# Altium schematic sheet pay-motors-prototype.SchDoc(pay-motors-prototype)
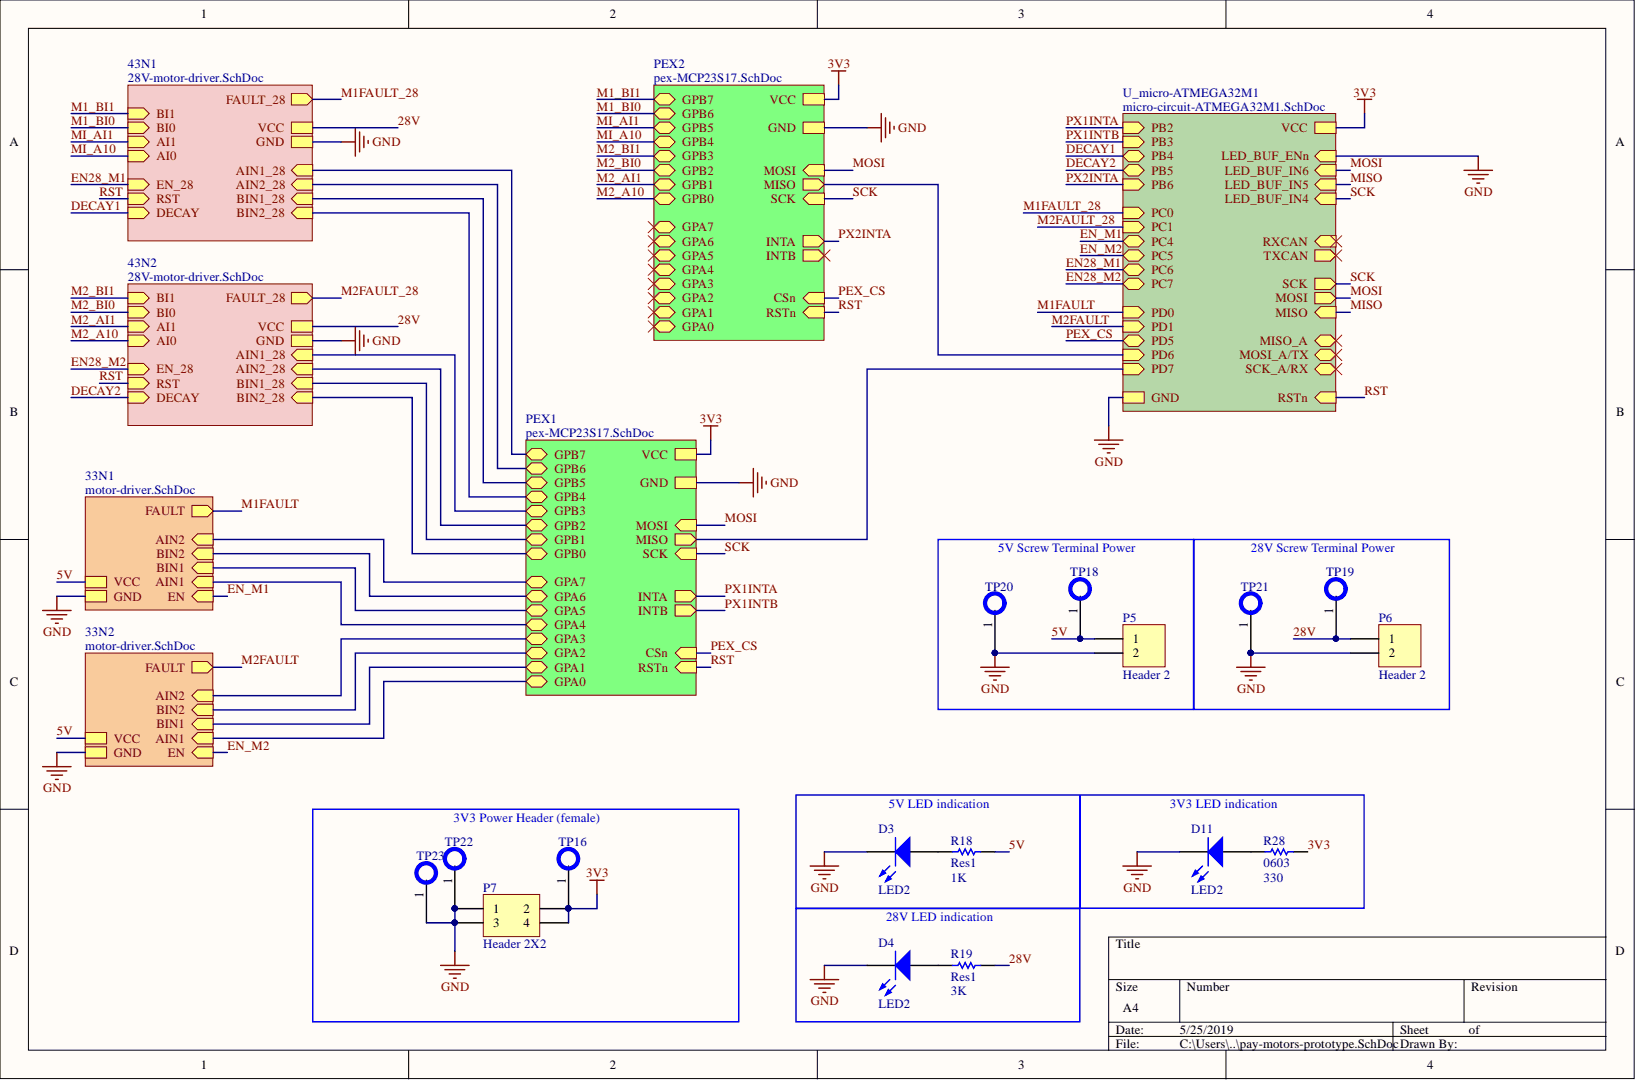
[diagram p0: pay-motors-prototype.SchDoc]

## Parts
D3  LED2  [0603-LED]
D4  LED2  [0603-LED]
D11  LED2  [0603-LED]
P5  Header 2  [CON2_SCREW_5.00MM_691102710002]
P6  Header 2  [CON2_SCREW_5.00MM_691102710002]
P7  Header 2X2  [HDR2X2]
R18  Res1  value 1K  [0603-RES]
R19  Res1  value 3K  [0603-RES]
R28  0603  value 330  [0603-RES]
TP16  Oscilloscope Test Point  [KEYSTONE_TP5000]  (value not drawn)
TP18  Oscilloscope Test Point  [KEYSTONE_TP5000]  (value not drawn)
TP19  Oscilloscope Test Point  [KEYSTONE_TP5000]  (value not drawn)
TP20  Oscilloscope Test Point  [KEYSTONE_TP5000]  (value not drawn)
TP21  Oscilloscope Test Point  [KEYSTONE_TP5000]  (value not drawn)
TP22  Oscilloscope Test Point  [KEYSTONE_TP5000]  (value not drawn)
TP23  Oscilloscope Test Point  [KEYSTONE_TP5000]  (value not drawn)

## Nets
GND: D3.2 D4.2 D11.2 P5.2 P6.2 P7.1 P7.3 TP20.1 TP21.1 TP22.1 TP23.1
3V3: P7.2 P7.4 R28.2 TP16.1
5V: P5.1 R18.2 TP18.1
28V: P6.1 R19.2 TP19.1
NetD3_1: D3.1 R18.1
NetD4_1: D4.1 R19.1
NetD11_1: D11.1 R28.1
AIN1:
AIN1_28:
AIN2:
AIN2_28:
BIN1:
BIN1_28:
BIN2:
BIN2_28:
DECAY1:
DECAY2:
EN28_M1:
EN28_M2:
EN_M1:
EN_M2:
GPA0:
GPA1:
GPA2:
GPA3:
GPA4:
GPA5:
GPA6:
GPA7:
INTB:
M1_BI0:
M1_BI1:
M1FAULT:
M1FAULT_28:
M2_A10:
M2_AI1:
M2_BI0:
M2_BI1:
M2FAULT:
M2FAULT_28:
MI_A10:
MI_AI1:
MISO:
MISO_A:
MOSI:
MOSI_A/TX:
PEX_CS:
PX1INTA:
PX1INTB:
PX2INTA:
RST:
RXCAN:
SCK:
SCK_A/RX:
TXCAN:

## Library data of the parts
D3 D4 D11 = LED2: Typical RED, GREEN, YELLOW, AMBER GaAs LED; Simulation: LED2; Published: 8-Jun-2000; PackageVersion: Feb-2002; PackageReference: 3.2X1.6X1.1; PackageDescription: SM LED; 2 Flat Leads; Library Name: Miscellaneous Devices.IntLib; LatestRevisionNote: Re-released for DXP Platform.; LatestRevisionDate: 17-Jul-2002; Component Kind: Standard; Publisher: Altium Limited
P5 P6 = Header 2: Header, 2-Pin; Signal Integrity: Connector; Library Name: Miscellaneous Connectors.IntLib; LatestRevisionNote: Re-released for DXP Platform.; LatestRevisionDate: 17-Jul-2002; Component Kind: Standard; Publisher: Altium Limited
P7 = Header 2X2: Header, 2-Pin, Dual row; Signal Integrity: Connector; Library Name: Miscellaneous Connectors.IntLib; LatestRevisionNote: Re-released for DXP Platform.; LatestRevisionDate: 17-Jul-2002; Component Kind: Standard; Publisher: Altium Limited
R18 = Res1: Resistor; Value: 1K; Simulation: RESISTOR; Signal Integrity: Res; Published: 8-Jun-2000; PackageReference: AXIAL-0.3; PackageDescription: Axial Device, Thru-Hole; 2 Leads; 0.3 in Pin Spacing; Library Name: Miscellaneous Devices.IntLib; LatestRevisionNote: Re-released for DXP Platform.; LatestRevisionDate: 17-Jul-2002; ComponentLink1URL: https://www.digikey.ca/product-detail/en/vishay-beyschlag/MCT06030C1001FP500/MCT0603-1.00K-CFCT-ND/2607875; ComponentLink1Description: Digikey Link; Component Kind: Standard; Publisher: Altium Limited
R19 = Res1: Resistor; Value: 3K; Simulation: RESISTOR; Signal Integrity: Res; Published: 8-Jun-2000; PackageReference: AXIAL-0.3; PackageDescription: Axial Device, Thru-Hole; 2 Leads; 0.3 in Pin Spacing; Library Name: Miscellaneous Devices.IntLib; LatestRevisionNote: Re-released for DXP Platform.; LatestRevisionDate: 17-Jul-2002; ComponentLink1URL: https://www.digikey.ca/product-detail/en/vishay-beyschlag/MCT06030C3001FP500/MCT0603-3.00K-CFCT-ND/2607899; ComponentLink1Description: Digikey Link; Component Kind: Standard; Publisher: Altium Limited
R28 = Res1: Resistor; Value: 330; Simulation: RESISTOR; Signal Integrity: Res; Published: 8-Jun-2000; PackageReference: AXIAL-0.3; PackageDescription: Axial Device, Thru-Hole; 2 Leads; 0.3 in Pin Spacing; Library Name: Miscellaneous Devices.IntLib; LatestRevisionNote: Re-released for DXP Platform.; LatestRevisionDate: 17-Jul-2002; ComponentLink1URL: https://www.digikey.ca/product-detail/en/yageo/RC0603FR-07330RL/311-330HRCT-ND/730109; ComponentLink1Description: Digikey Link; Component Kind: Standard; Publisher: Altium Limited
TP16 TP18-TP23 = P_KEYSTONE_5000: Red PC Test Point, Miniature Phosphor Bronze, Silver Plating 0.040" (1.02mm) Hole Diameter Mounting Type; Component Kind: Standard; Library Name: PAY.SchLib
